# Altium schematic sheet POWER.SchDoc
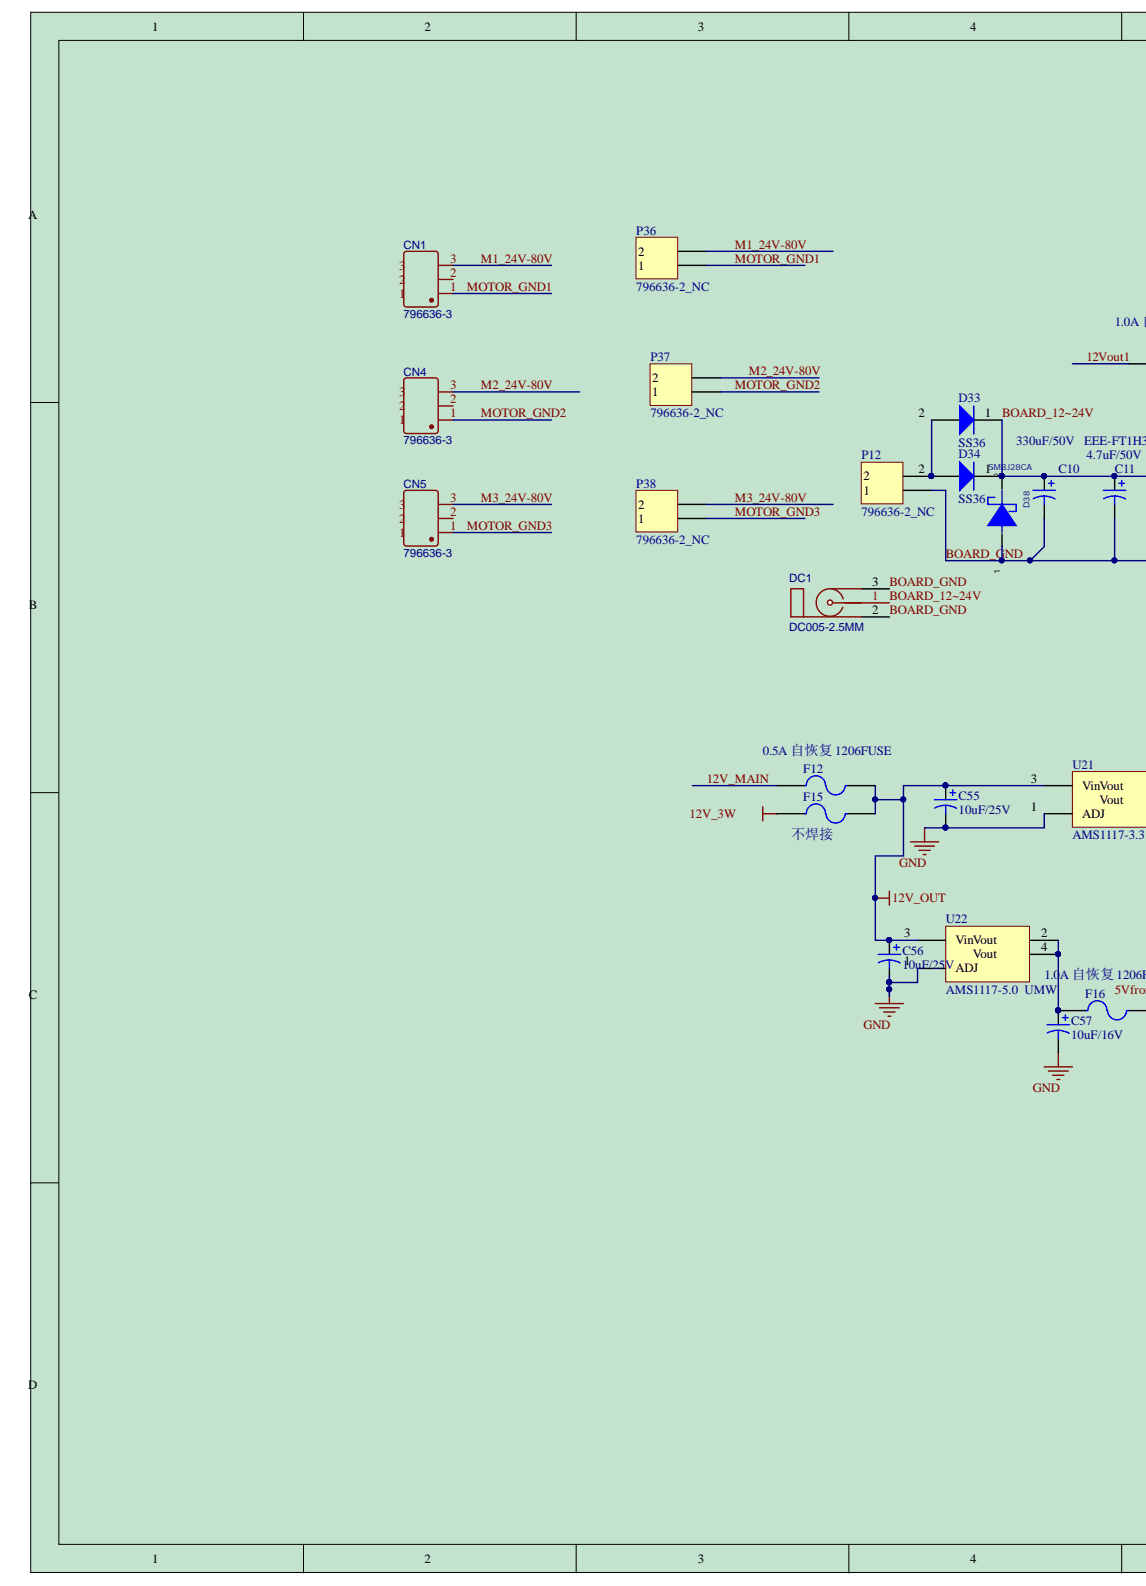
[diagram p0: POWER.SchDoc, left]
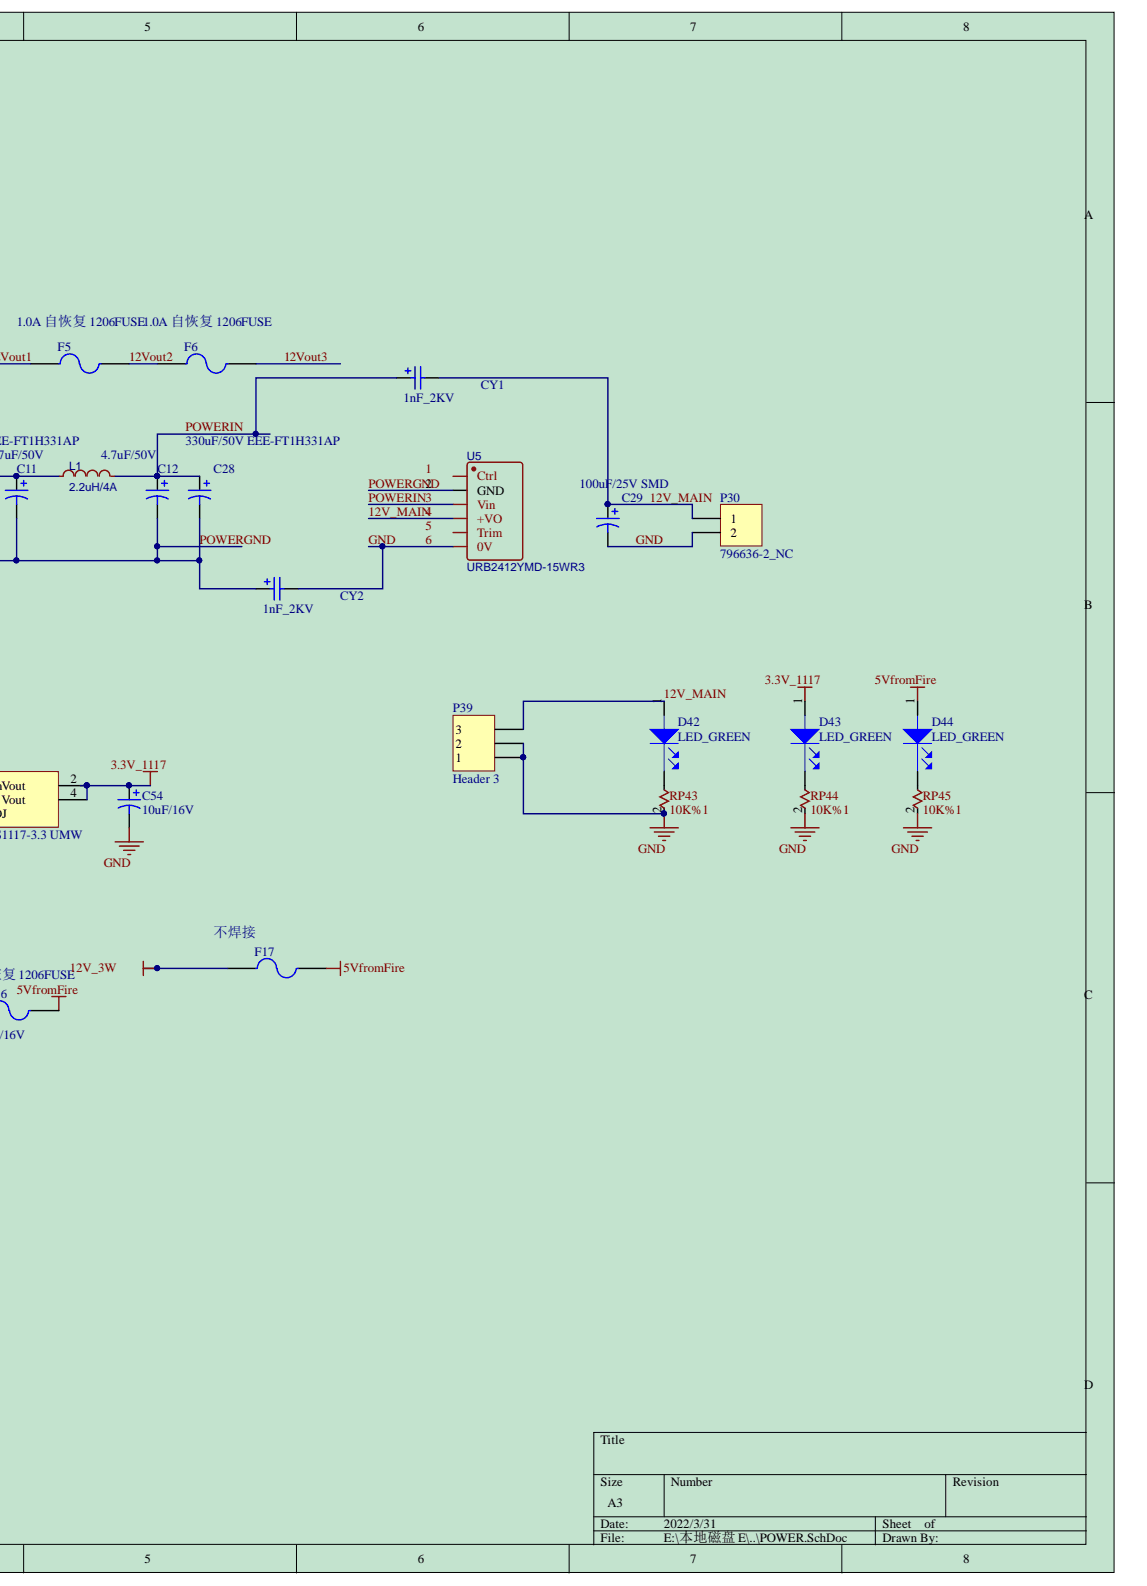
[diagram p1: POWER.SchDoc, right]

## Parts
C10  330uF/50V   EEE-FT1H331AP  [EEE0JA152UAP]
C11  4.7uF/50V  [C1210_N]
C12  4.7uF/50V  [C1210_N]
C28  330uF/50V EEE-FT1H331AP  [EEE0JA152UAP]
C29  100uF/25V SMD  [10*12]
C54  10uF/16V  [cap1210]
C55  10uF/25V  [cap1210]
C56  10uF/25V  [cap1210]
C57  10uF/16V  [cap1210]
CN1  796636-3  [796636-3]
CN4  796636-3  [796636-3]
CN5  796636-3  [796636-3]
CY1  1nF_2KV  [CAP1210]
CY2  1nF_2KV  [CAP1210]
D33  SS36  [SMB_D]
D34  SS36  [SMB_D]
D38  SMBJ28CA  [SMB_双向]
D42  LED_GREEN  [LED0603]
D43  LED_GREEN  [LED0603]
D44  LED_GREEN  [LED0603]
DC1  DC005-2.5MM  [DC-IN-TH_DC-005-20A-1]
F5  1.0A 自恢复 1206FUSE  [1206_FUSE]
F6  1.0A 自恢复 1206FUSE  [1206_FUSE]
F12  0.5A 自恢复 1206FUSE  [1206_FUSE]
F15  不焊接  [1206_FUSE]
F16  1.0A 自恢复 1206FUSE  [1206_FUSE]
F17  不焊接  [1206_FUSE]
L1  2.2uH/4A  [IND-SMD_L4.5-W4.0_SCD0403T]
P12  796636-2_NC  [pitch5082 _d_1.4_FINAL]
P30  796636-2_NC  [pitch5082 _d_1.4_FINAL]
P36  796636-2_NC  [pitch5082 _d_1.4_FINAL]
P37  796636-2_NC  [pitch5082 _d_1.4_FINAL]
P38  796636-2_NC  [pitch5082 _d_1.4_FINAL]
P39  Header 3  [HDR1X3]
RP43  10K%1  [R0805]
RP44  10K%1  [R0805]
RP45  10K%1  [R0805]
U5  URB2412YMD-15WR3  [PWRM-TH_URBXXXXYMD-15WR3]
U21  AMS1117-3.3 UMW  [SOT223]
U22  AMS1117-5.0  UMW  [SOT223]

## Nets
3.3V_1117: C54.1 D43.1 U21.2 U21.4
5VFROMFIRE: D44.1 F16.2 F17.2
12V_3W: F15.1 F17.1
12V_OUT: C55.1 C56.1 F12.2 F15.2 U21.3(Vin) U22.3(Vin)
12V_MAIN: C29.1 CY1.2 D42.1 F12.1 P30.1 P39.3 U5.4(+VO)
12VOUT1: F5.1
12VOUT2: F5.2 F6.1
12VOUT3: F6.2
BOARD_12~24V: C10.1 C11.1 D33.1 D34.1 D38.2 DC1.1 L1.1
BOARD_GND: C10.2 C11.2 C12.2 C28.2 CY2.1 D38.1 DC1.2 DC1.3 P12.1 U5.2(GND)
GND: C29.2 C54.2 C55.2 C56.2 C57.2 CY2.2 P30.2 P39.1 P39.2 RP43.2 RP44.2 RP45.2 U5.6(0V) U21.1(ADJ) U22.1(ADJ)
M1_24V-80V: CN1.3 P36.2
M2_24V-80V: CN4.3 P37.2
M3_24V-80V: CN5.3 P38.2
MOTOR_GND1: CN1.1 P36.1
MOTOR_GND2: CN4.1 P37.1
MOTOR_GND3: CN5.1 P38.1
POWERIN: C12.1 C28.1 CY1.1 L1.2 U5.3(Vin)
NetC57_1: C57.1 F16.1 U22.2 U22.4
NetD33_2: D33.2 D34.2 P12.2
NetD42_2: D42.2 RP43.1
NetD43_2: D43.2 RP44.1
NetD44_2: D44.2 RP45.1

Not connected: CN1 2; CN4 2; CN5 2; U5 1(Ctrl) 5(Trim)

## Library data of the parts
C10-C12 C28 C29 C54-C57 = Cap Pol2: Polarized Capacitor (Axial); Value: 10uF; Component Kind: Standard; Library Name: Miscellaneous Devices.IntLib; Published: 8-Jun-2000; Revision: July-2002: Re-released for DXP Platform.; Signal Integrity: Cap Pol; Simulation: CAP; Publisher: Altium Limited
CN1 CN4 CN5 = 796636-3: BOM_Manufacturer: TE Connectivity; Contributor: LCSC; BOM_JLCPCB Part Class: Extended Part; BOM_Manufacturer Part: 796636-3; BOM_Supplier: LCSC; BOM_Supplier Part: C306124; Component Kind: Standard; Library Name: Schlib1.SchLib; spicePre: C; spiceSymbolName: 796636-3
CY1 CY2 = Cap Pol2: Polarized Capacitor (Axial); Component Kind: Standard; LatestRevisionDate: 17-Jul-2002; LatestRevisionNote: Re-released for DXP Platform.; Library Name: Miscellaneous Devices.IntLib; PackageDescription: Polarized Capacitor; 2 Leads; PackageReference: POLAR0.8; Published: 8-Jun-2000; Signal Integrity: Cap Pol; Simulation: CAP; Publisher: Altium Limited
D33 D34 = Diode 1N4150: High Conductance Ultra Fast Diode; Code - JEDEC: DO-204-AH; Revision 1: 11-Jul-2000: SIM Model Added.; Revision 2: 17-Jul-2002: Re-released for DXP Platform.; Component Kind: Standard; Datasheet: Latest Revision: 1997; Library Name: Miscellaneous Devices.IntLib; Package Reference: DO-35; Published: 8-Jun-2000; Signal Integrity: 1N4150; Simulation: 1N4150; Publisher: Altium Limited
D38 = SMAJ5.0CA: SMBJ13CA; PCB DECAL: DO-214; Component Kind: Standard; Library Name: F429_F746_F767_MB.SCHLIB; Manufacture 1 P/N: SMAJ5.0CA; Value: SMBJ28CA
D42-D44 = LED_0: LED GRN SGL 25MA 0603; Component Kind: Standard; Library Name: 野火_F429_V2核心板_原理图封装库_AD格式.lib
DC1 = DC005-2.5MM: BOM_Manufacturer: SOFNG; BOM_JLCPCB Part Class: Extended Part; BOM_Manufacturer Part: DC005-2.5MM; BOM_Supplier: LCSC; BOM_Supplier Part: C111573; Component Kind: Standard; Library Name: Schlib1.SchLib; spicePre: D; spiceSymbolName: DC005-2.5MM
F5 F6 F12 F15-F17 = Fuse 2: Fuse; Component Kind: Standard; LatestRevisionDate: 17-Jul-2002; LatestRevisionNote: Re-released for DXP Platform.; PackageReference: PIN-W2/E2.8; Published: 8-Jun-2000; Simulation: FUSE; Library Name: jinrylib.IntLib; Publisher: Altium Limited
L1 = 2.2uH: BOM_Manufacturer: COILMX; BOM_Manufacturer Part: MSA43-2R2M; Contributor: LCSC; nameAlias: Inductance; BOM_JLCPCB Part Class: Extended Part; BOM_Supplier: LCSC; BOM_Supplier Part: C396394; Component Kind: Standard; Library Name: Schlib1.SchLib; spicePre: L; spiceSymbolName: MSA43-2R2M
P12 P30 P36-P38 = Header 2: Header, 2-Pin; Component Kind: Standard; Library Name: Miscellaneous Connectors.IntLib; Revision: 17-Jul-2002: Re-released for DXP Platform.; Signal Integrity: Connector; Publisher: Altium Limited
P39 = Header 3: Header, 3-Pin; Component Kind: Standard; LatestRevisionDate: 17-Jul-2002; LatestRevisionNote: Re-released for DXP Platform.; Library Name: Miscellaneous Connectors.IntLib; Signal Integrity: Connector; Publisher: Altium Limited
RP43-RP45 = RESISTOR: Generic Resistor; Value: 4.7K; Component Kind: Standard; Library Name: Digilent.IntLib
U5 = URB2412YMD-15WR3: BOM_Manufacturer: MORNSUN(金升阳); Contributor: LCSC; BOM_JLCPCB Part Class: Extended Part; BOM_Manufacturer Part: URB2412YMD-15WR3; BOM_Supplier: LCSC; BOM_Supplier Part: C2687391; Component Kind: Standard; Library Name: Schlib1.SchLib; spicePre: U; spiceSymbolName: URB2412YMD-15WR3
U21 U22 = LM1117IMPX-ADJ/NOPB: Component Kind: Standard; Library Name: flow.SchLib
